# Altium schematic sheet STMwithBut&Leds.SchDoc
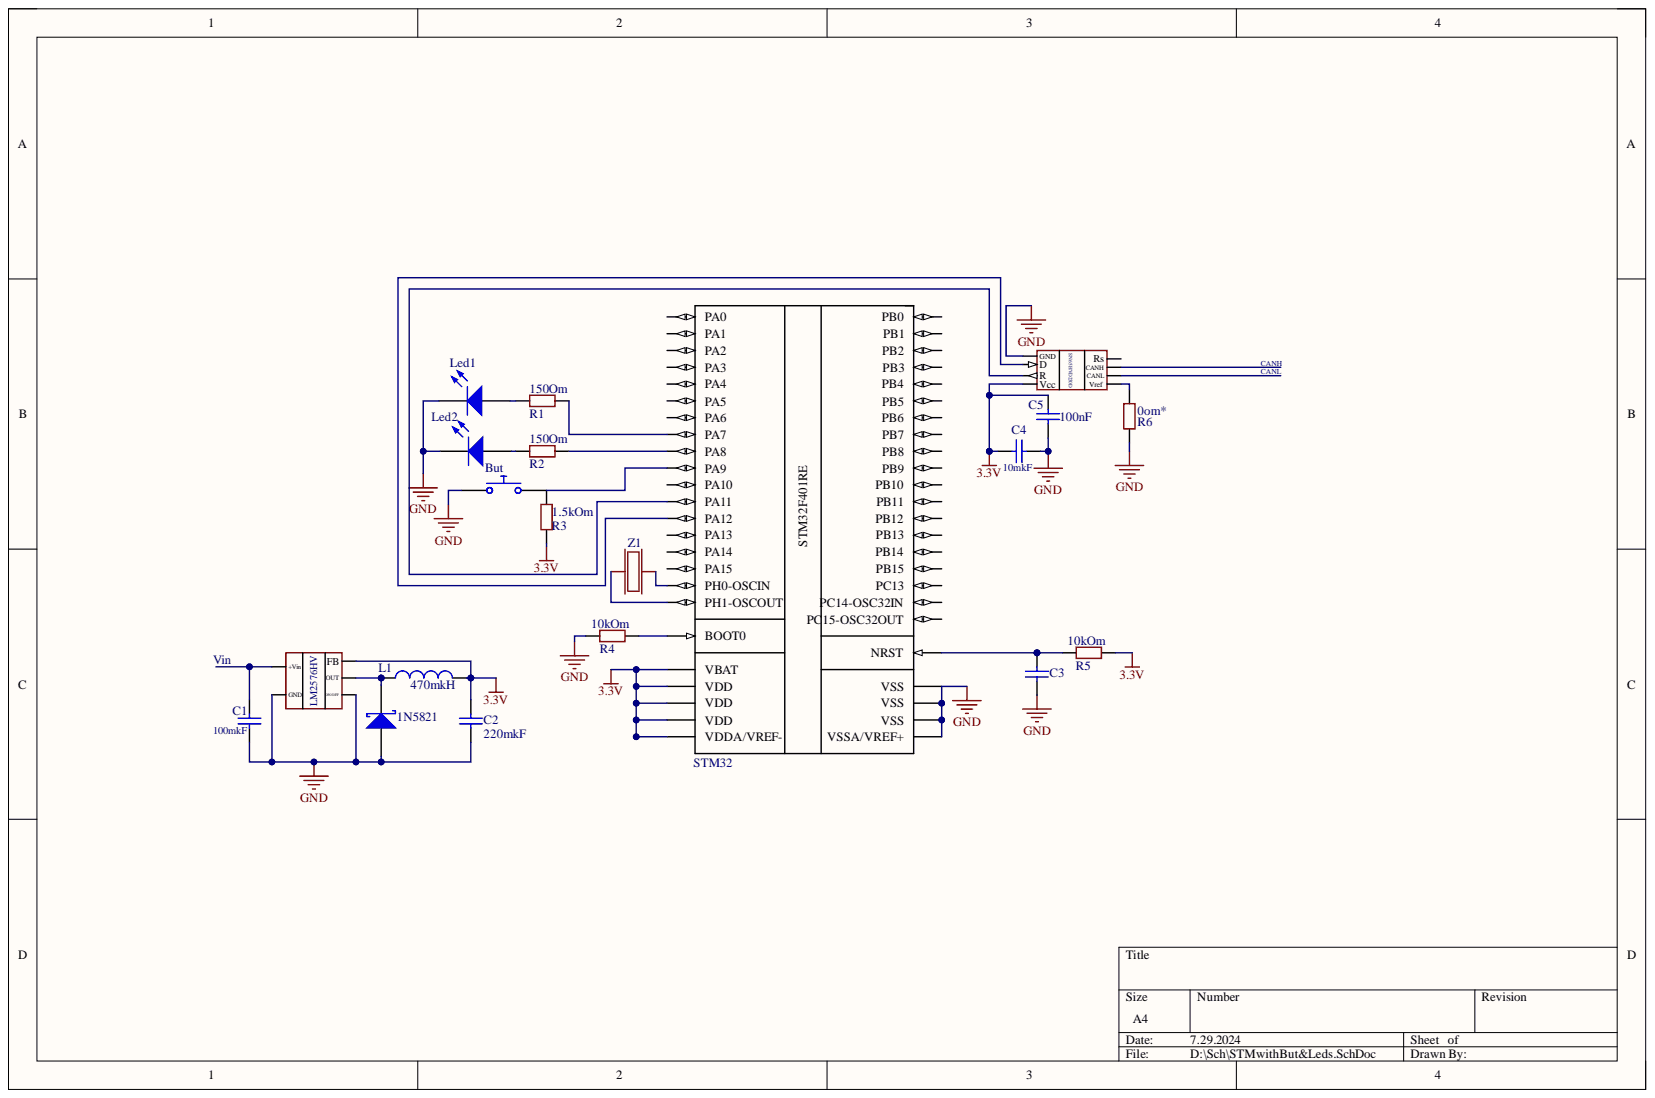
[diagram p0: STMwithBut&Leds.SchDoc]

## Parts
0om*  R6
1.5kOm  R3
1N5821  Schottky Diode  (value not drawn)
10kOm  R5
10kOm  R4
10mkF  Capacitor  (value not drawn)
100nF  Capacitor  (value not drawn)
150Om  R2
150Om  R1
470mkH  Inductor  (value not drawn)
*  Component_1  (value not drawn)
*
*  STM32
But  Push Button Normally Opened  (value not drawn)
C1  value 100mkF  (hidden comment: Capacitor)
C2  value 220mkF  (hidden comment: Capacitor)
C3  Capacitor  (value not drawn)
Led1  Light-Emitting Diode  (value not drawn)
Led2  Light-Emitting Diode  (value not drawn)
Z1  Кварц  (value not drawn)

## Nets
3.3V: 1.5kOm.8 10kOm.9 10mkF.1 100nF.1 470mkH.2 *.25(FB) *. C2.2
GND: 0om*.8 1N5821.1 10kOm.8 10mkF.2 100nF.2 *.21 *.23(ON/OFF) *.27(GND) *. But.1 C1.1 C2.1 C3.2 Led1.2 Led2.2
Net*: *.21 *. Z1.Q? Z1.
Net0om*_9: 0om*.9 *.22(Vref)
Net1.5kOm_9: 1.5kOm.9 *. But.2
Net1N5821_2: 1N5821.2 470mkH.1 *.24(OUT)
Net10kOm_8: 10kOm.8 *. C3.1
Net10kOm_9: 10kOm.9 *.
Net150Om_8: 150Om.8 Led1.1 Led2.1
Net150Om_9: 150Om.9 *.
Net*_26: *.26(+Vin) C1.2

## Library data of the parts
0om* 1.5kOm 10kOm 10kOm 150Om 150Om * = Component_1: Component Kind: Standard
  0om* 1.5kOm 10kOm 10kOm 150Om 150Om: Library Name: Res.SchLib
  *: Library Name: STM32.SchLib
1N5821 = Schottky Diode: AF: 1; BV: 0; BV_MAX: 1e99; EG: 1.11; FC: 0.5; IBV: 0.001; IS: 1e-14; MJSW: 0.33; NTUN: 30; SimulationGenericComponent: True; TNOM: 27; XTI: 3; CJO: 0; CJP: 0; Component Kind: Standard; CTA: 0; CTP: 0; Description: Schottky Diode; FCS: 0.5; FV_MAX: 1e99; IKF: 0; IKR: 0; ISR: 1e-14; JSW: 0; JTUN: 0; JTUNSW: 0; KEG: 1; KF: 0; LEVEL: 1; Library Name: Simulation Generic Components.IntLib; N: 1; NBV: 1; NR: 1; NS: 1; PHP: 1; RS: 0; Simulation: diode; TCV: 0; TLEV: 0; TLEVC: 0; TM1: 0; TM2: 0; TPB: 0; TPHP: 0; TRS: 0; TRS2: 0; TT: 0; TTT1: 0; TTT2: 0; VJ: 1; XTITUN: 3
10mkF 100nF C1-C3 = Capacitor: SimulationGenericComponent: True; Component Kind: Standard; Description: Capacitor; Library Name: Simulation Generic Components.IntLib; Simulation: C_Capacitor_Default
470mkH = Inductor: L1: 1u; SimulationGenericComponent: True; Component Kind: Standard; Description: Inductor; Library Name: Simulation Generic Components.IntLib; Simulation: L_Inductor_Default
But = Push Button NO: closed: 0; Rclosed: 1m; Ropen: 1Meg; SimulationGenericComponent: True; Component Kind: Standard; Description: Push Button Normally Opened; Library Name: Simulation Generic Components.IntLib; Simulation: PUSH_BUTTON_NO
Led1 Led2 = LED: AF: 1; BV: 0; BV_MAX: 1e99; EG: 1.11; FC: 0.5; IBV: 0.001; IS: 1e-14; MJSW: 0.33; NTUN: 30; SimulationGenericComponent: True; TNOM: 27; XTI: 3; CJO: 0; CJP: 0; Component Kind: Standard; CTA: 0; CTP: 0; Description: Light-Emitting Diode; FCS: 0.5; FV_MAX: 1e99; IKF: 0; IKR: 0; ISR: 1e-14; JSW: 0; JTUN: 0; JTUNSW: 0; KEG: 1; KF: 0; LEVEL: 1; Library Name: Simulation Generic Components.IntLib; N: 1; NBV: 1; NR: 1; NS: 1; PHP: 1; RS: 0; Simulation: diode; TCV: 0; TLEV: 0; TLEVC: 0; TM1: 0; TM2: 0; TPB: 0; TPHP: 0; TRS: 0; TRS2: 0; TT: 0; TTT1: 0; TTT2: 0; VJ: 1; XTITUN: 3
* = Component_1: Component Kind: Standard; Library Name: LM2576HV.SchLib
Z1 = Кварц: Component Kind: Standard; Library Name: STM32F401REll.SchLib
